# Altium schematic sheet [11] - HDMI INPUT.SchDoc("[11] - HDMI INPUT")
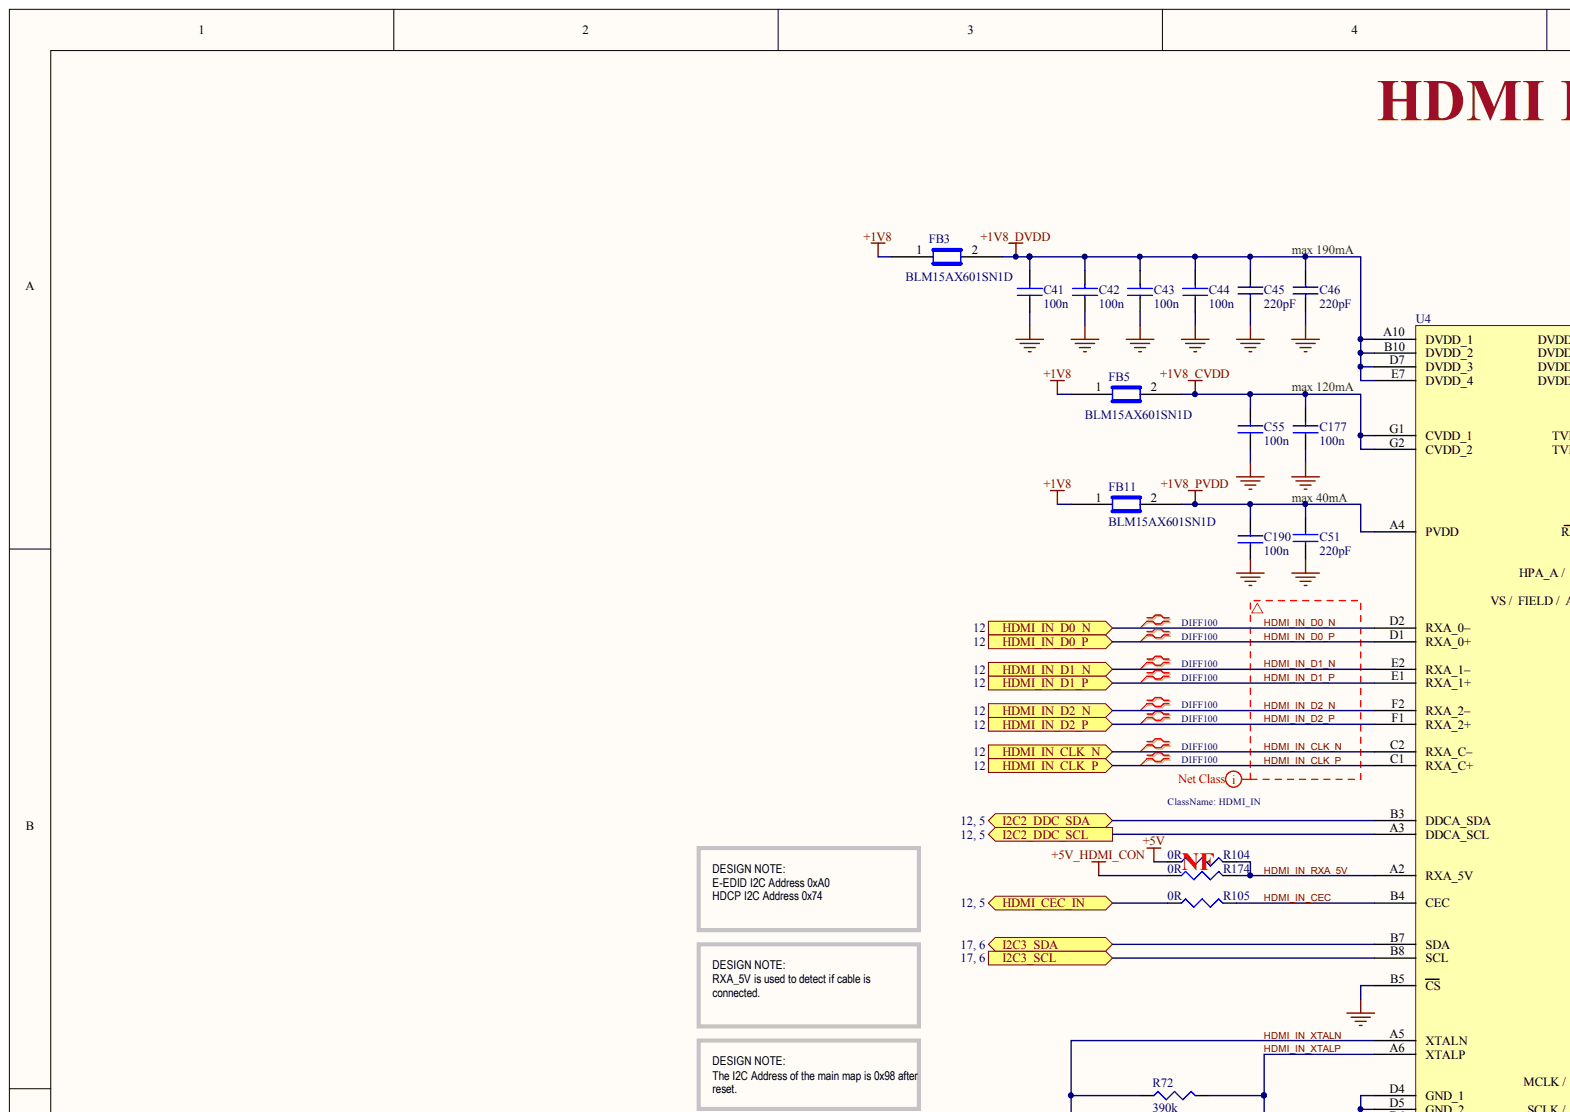
[diagram p0: [11] - HDMI INPUT.SchDoc, top left]
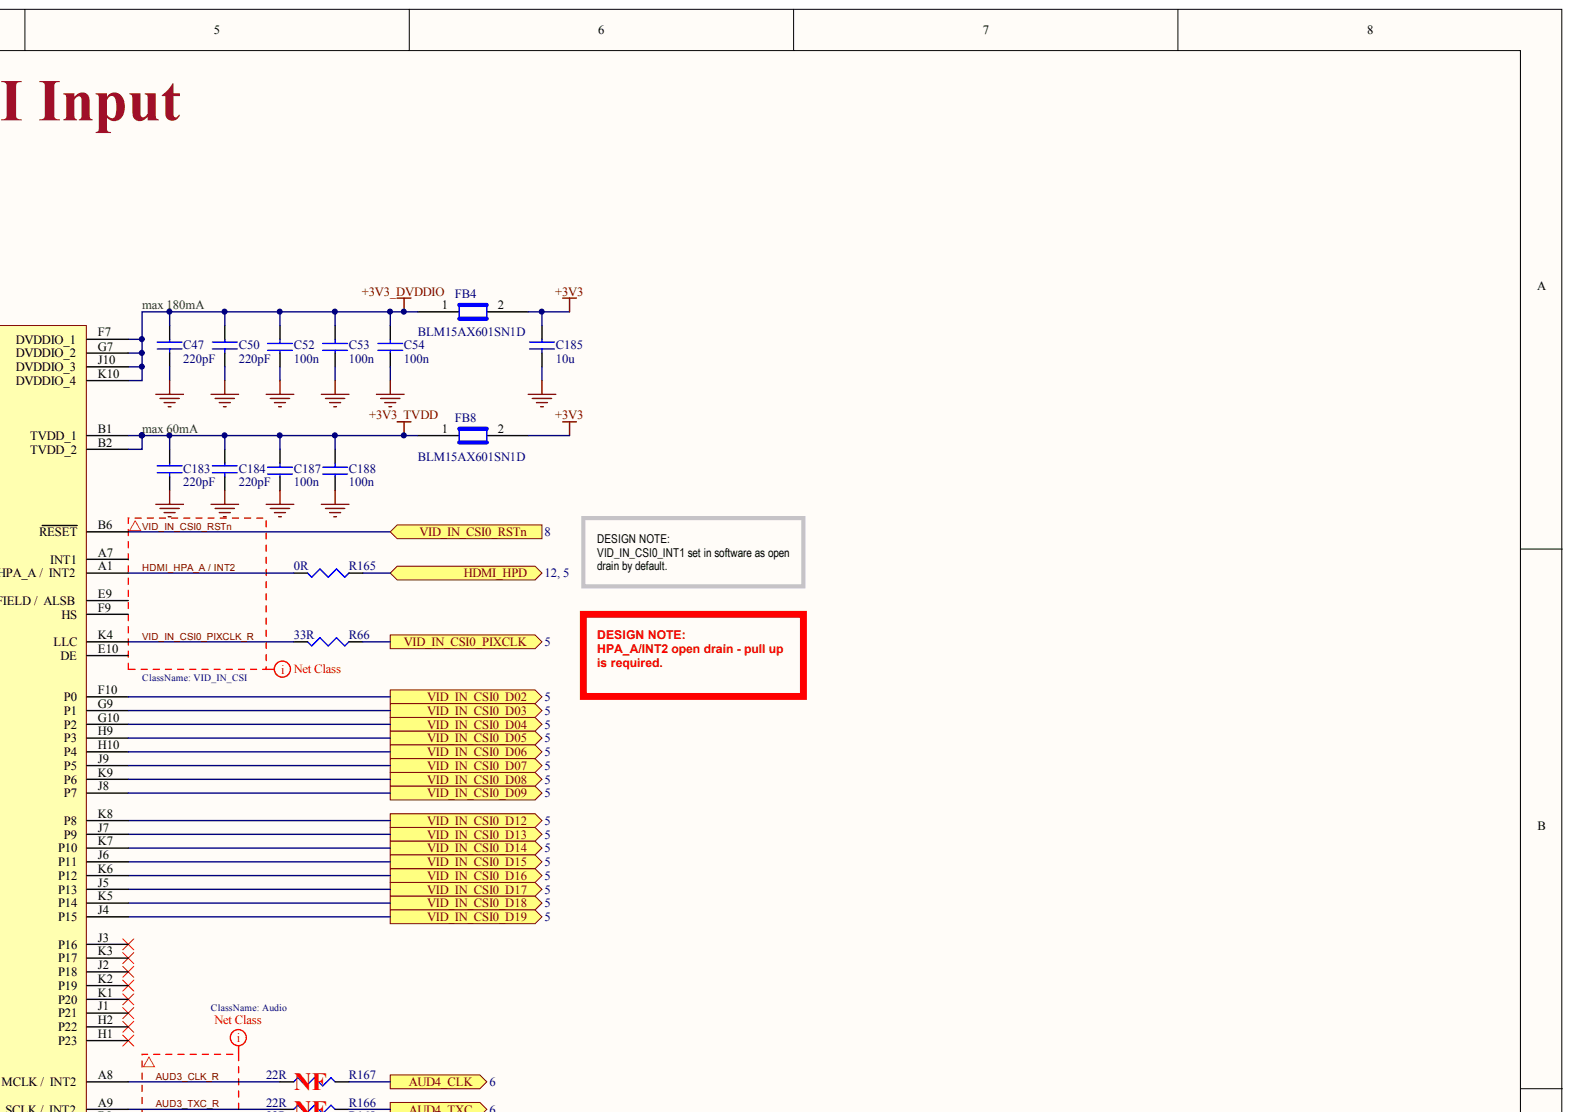
[diagram p1: [11] - HDMI INPUT.SchDoc, top right]
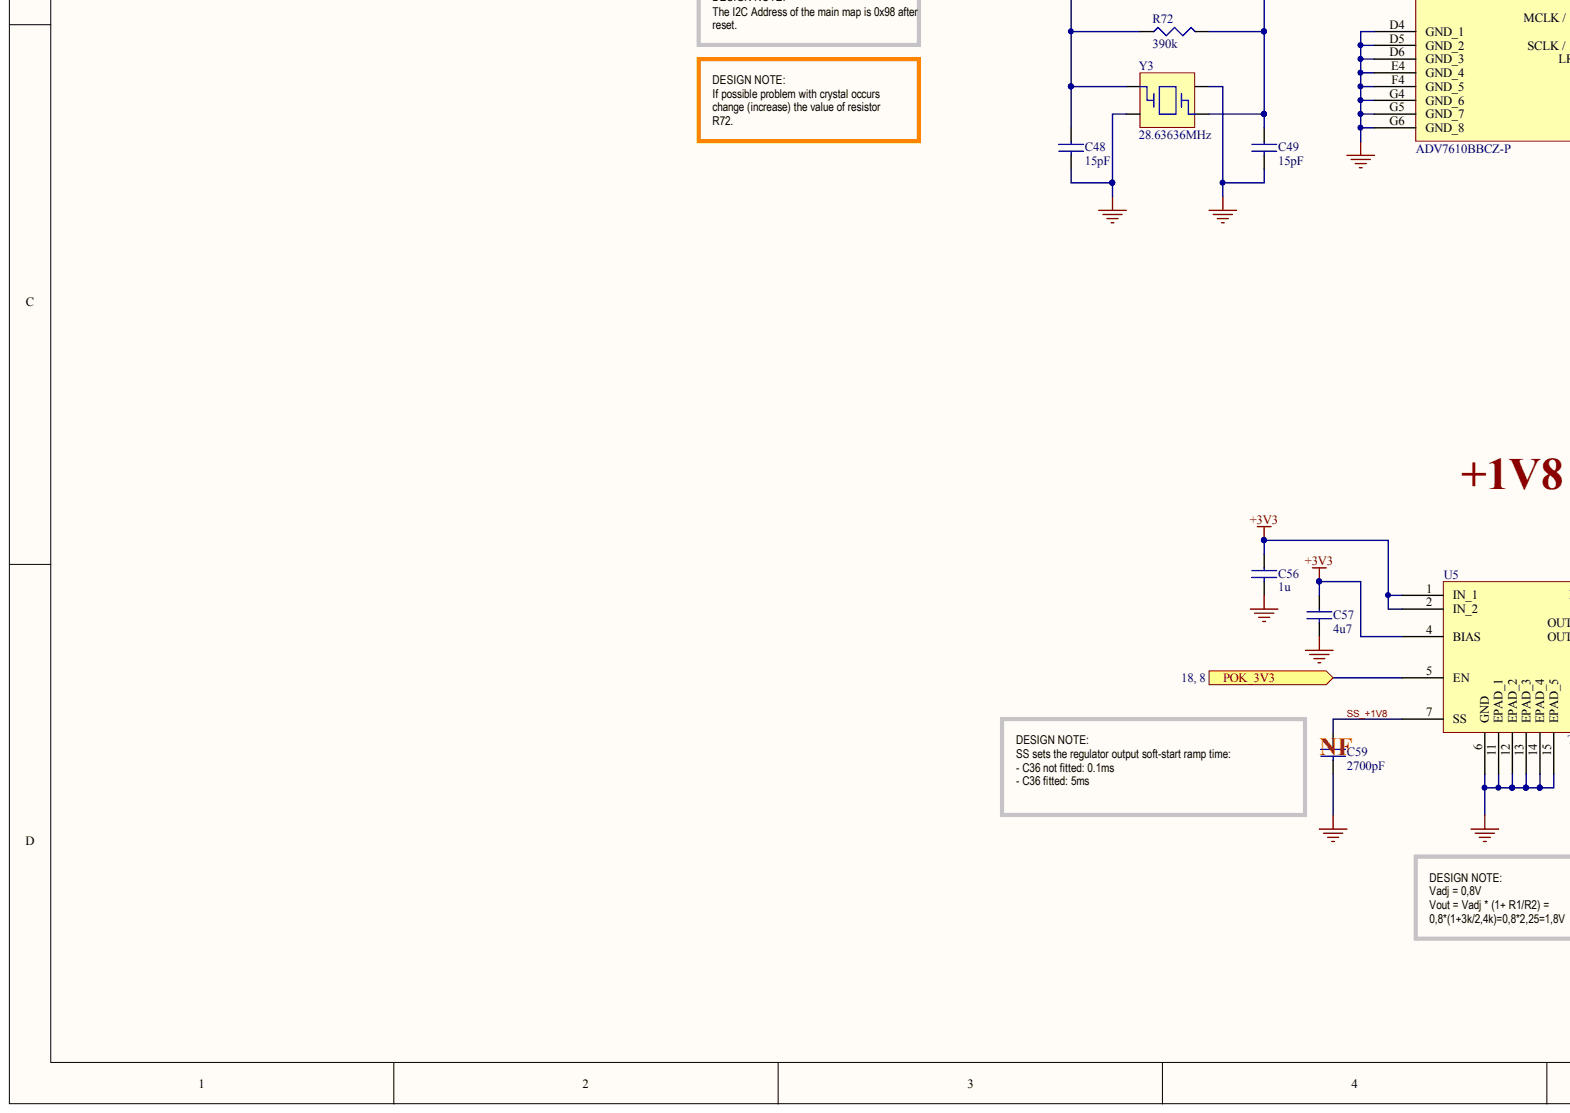
[diagram p2: [11] - HDMI INPUT.SchDoc, bottom left]
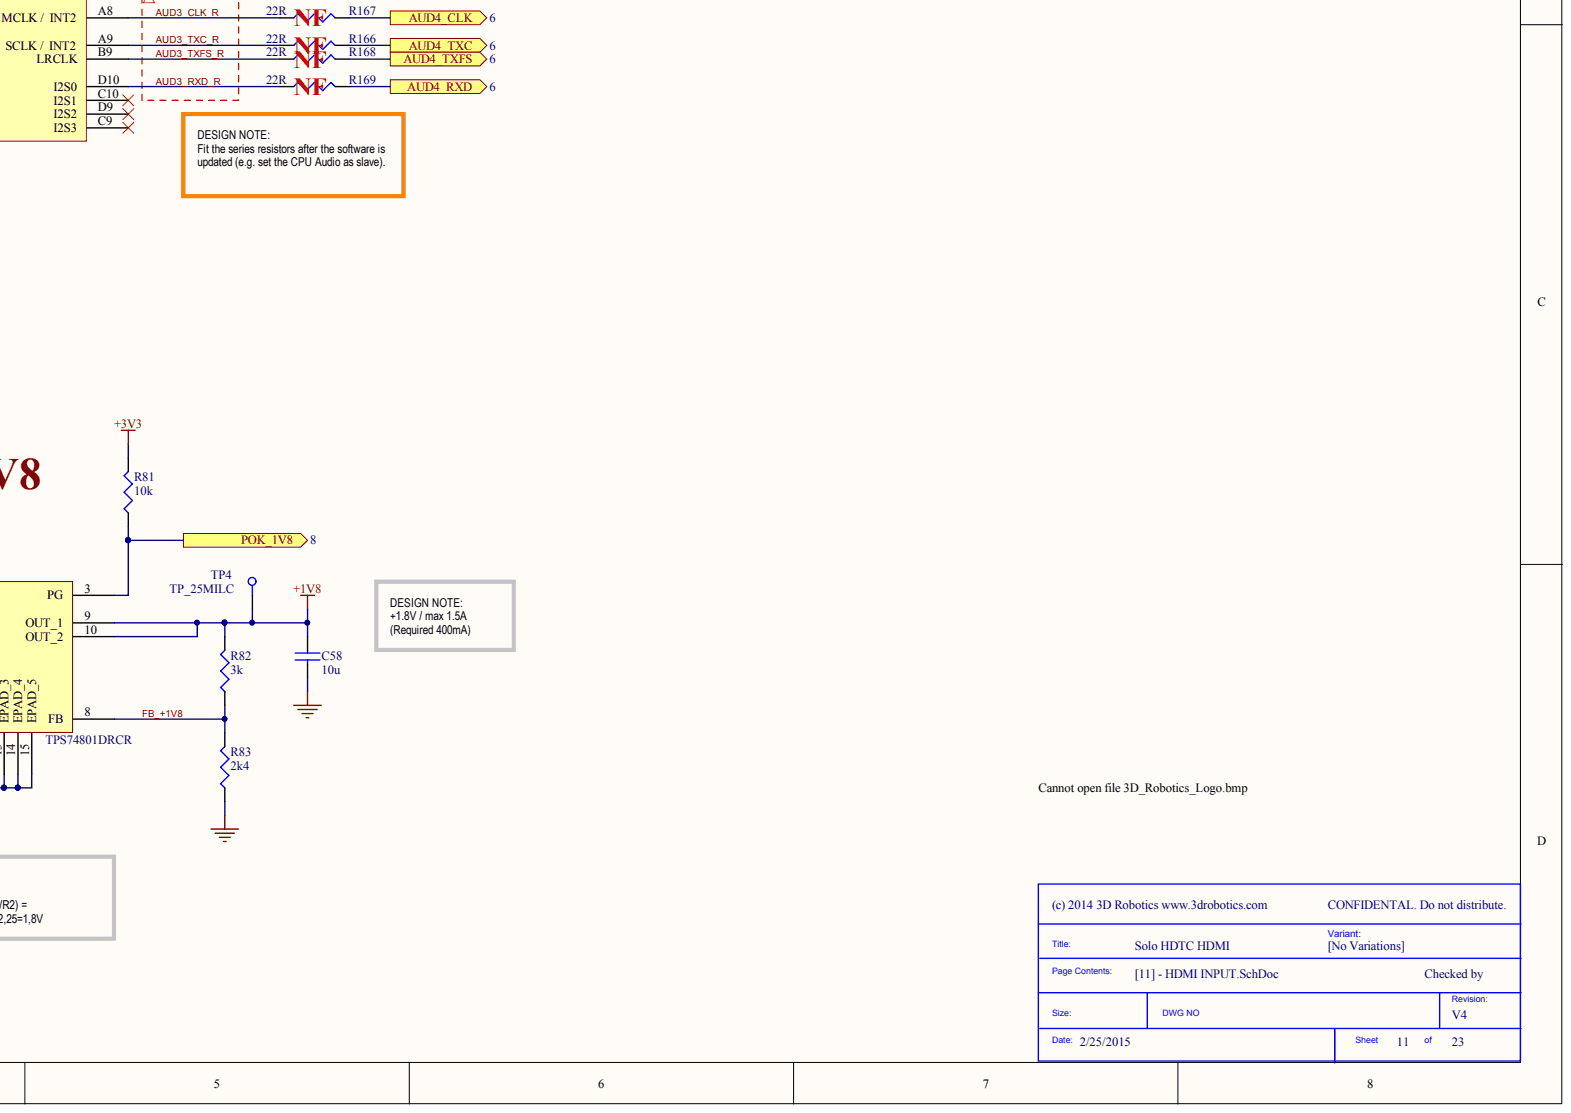
[diagram p3: [11] - HDMI INPUT.SchDoc, bottom right]

## Parts
C41  100n
C42  100n
C43  100n
C44  100n
C45  220pF
C46  220pF
C47  220pF
C48  15pF
C49  15pF
C50  220pF
C51  220pF
C52  100n
C53  100n
C54  100n
C55  100n
C56  1u
C57  4u7
C58  10u
C59  2700pF
C177  100n
C183  220pF
C184  220pF
C185  10u
C187  100n
C188  100n
C190  100n
FB3  BLM15AX601SN1D
FB4  BLM15AX601SN1D
FB5  BLM15AX601SN1D
FB8  BLM15AX601SN1D
FB11  BLM15AX601SN1D
R66  33R
R72  390k
R81  10k
R82  3k
R83  2k4
R104  0R
R105  0R
R165  0R
R166  22R
R167  22R
R168  22R
R169  22R
R174  0R
TP4  TP_25MILC
U4  ADV7610BBCZ-P
U5  TPS74801DRCR
Y3  28.63636MHz

## Ports
AUD4_CLK, AUD4_RXD, AUD4_TXC, AUD4_TXFS, HDMI_CEC_IN, HDMI_HPD, HDMI_IN_CLK_N, HDMI_IN_CLK_P, HDMI_IN_D0_N, HDMI_IN_D0_P, HDMI_IN_D1_N, HDMI_IN_D1_P, HDMI_IN_D2_N, HDMI_IN_D2_P, I2C2_DDC_SCL, I2C2_DDC_SDA, I2C3_SCL, I2C3_SDA, POK_1V8, POK_3V3, VID_IN_CSI0_D02, VID_IN_CSI0_D03, VID_IN_CSI0_D04, VID_IN_CSI0_D05, VID_IN_CSI0_D06, VID_IN_CSI0_D07, VID_IN_CSI0_D08, VID_IN_CSI0_D09, VID_IN_CSI0_D12, VID_IN_CSI0_D13, VID_IN_CSI0_D14, VID_IN_CSI0_D15, VID_IN_CSI0_D16, VID_IN_CSI0_D17, VID_IN_CSI0_D18, VID_IN_CSI0_D19, VID_IN_CSI0_PIXCLK, VID_IN_CSI0_RSTN

## Nets
+1V8: C58.1 FB3.1 FB5.1 FB11.1 R82.2 TP4.1 U5.9(OUT_1) U5.10(OUT_2)
+1V8_CVDD: C55.2 C177.2 FB5.2 U4.G1(CVDD_1) U4.G2(CVDD_2)
+1V8_DVDD: C41.2 C42.2 C43.2 C44.2 C45.1 C46.1 FB3.2 U4.A10(DVDD_1) U4.B10(DVDD_2) U4.D7(DVDD_3) U4.E7(DVDD_4)
+1V8_PVDD: C51.1 C190.2 FB11.2 U4.A4(PVDD)
+3V3: C56.1 C57.1 C185.1 FB4.2 FB8.2 R81.2 U5.1(IN_1) U5.2(IN_2) U5.4(BIAS)
+3V3_DVDDIO: C47.1 C50.1 C52.2 C53.2 C54.2 FB4.1 U4.F7(DVDDIO_1) U4.G7(DVDDIO_2) U4.J10(DVDDIO_3) U4.K10(DVDDIO_4)
+3V3_TVDD: C183.1 C184.1 C187.2 C188.2 FB8.1 U4.B1(TVDD_1) U4.B2(TVDD_2)
+5V: R104.1
+5V_HDMI_CON: R174.1
GND: C41.1 C42.1 C43.1 C44.1 C45.2 C46.2 C47.2 C48.2 C49.2 C50.2 C51.2 C52.1 C53.1 C54.1 C55.1 C56.2 C57.2 C58.2 C59.2 C177.1 C183.2 C184.2 C185.2 C187.1 C188.1 C190.1 R83.1 U4.B5(CS) U4.D4(GND_1) U4.D5(GND_2) U4.D6(GND_3) U4.E4(GND_4) U4.F4(GND_5) U4.G4(GND_6) U4.G5(GND_7) U4.G6(GND_8) U5.6(GND) U5.11(EPAD_1) U5.12(EPAD_2) U5.13(EPAD_3) U5.14(EPAD_4) U5.15(EPAD_5) Y3.2 Y3.4
AUD3_CLK_R: R167.1 U4.A8(INT2)
AUD3_RXD_R: R169.1 U4.D10(I2S0)
AUD3_TXC_R: R166.1 U4.A9(INT2)
AUD3_TXFS_R: R168.1 U4.B9(LRCLK)
AUD4_CLK: R167.2 ; port AUD4_CLK
AUD4_RXD: R169.2 ; port AUD4_RXD
AUD4_TXC: R166.2 ; port AUD4_TXC
AUD4_TXFS: R168.2 ; port AUD4_TXFS
FB_+1V8: R82.1 R83.2 U5.8(FB)
HDMI_CEC_IN: R105.1 ; port HDMI_CEC_IN
HDMI_HPA_A / INT2: R165.2 U4.A1(INT2)
HDMI_HPD: R165.1 ; port HDMI_HPD
HDMI_IN_CEC: R105.2 U4.B4(CEC)
HDMI_IN_CLK_N: U4.C2(RXA_C–) ; port HDMI_IN_CLK_N
HDMI_IN_CLK_P: U4.C1(RXA_C+) ; port HDMI_IN_CLK_P
HDMI_IN_D0_N: U4.D2(RXA_0–) ; port HDMI_IN_D0_N
HDMI_IN_D0_P: U4.D1(RXA_0+) ; port HDMI_IN_D0_P
HDMI_IN_D1_N: U4.E2(RXA_1–) ; port HDMI_IN_D1_N
HDMI_IN_D1_P: U4.E1(RXA_1+) ; port HDMI_IN_D1_P
HDMI_IN_D2_N: U4.F2(RXA_2–) ; port HDMI_IN_D2_N
HDMI_IN_D2_P: U4.F1(RXA_2+) ; port HDMI_IN_D2_P
HDMI_IN_RXA_5V: R104.2 R174.2 U4.A2(RXA_5V)
HDMI_IN_XTALN: C48.1 R72.1 U4.A5(XTALN) Y3.1
HDMI_IN_XTALP: C49.1 R72.2 U4.A6(XTALP) Y3.3
I2C2_DDC_SCL: U4.A3(DDCA_SCL) ; port I2C2_DDC_SCL
I2C2_DDC_SDA: U4.B3(DDCA_SDA) ; port I2C2_DDC_SDA
I2C3_SCL: U4.B8(SCL) ; port I2C3_SCL
I2C3_SDA: U4.B7(SDA) ; port I2C3_SDA
POK_1V8: R81.1 U5.3(PG) ; port POK_1V8
POK_3V3: U5.5(EN) ; port POK_3V3
SS_+1V8: C59.1 U5.7(SS)
VID_IN_CSI0_D02: U4.F10(P0) ; port VID_IN_CSI0_D02
VID_IN_CSI0_D03: U4.G9(P1) ; port VID_IN_CSI0_D03
VID_IN_CSI0_D04: U4.G10(P2) ; port VID_IN_CSI0_D04
VID_IN_CSI0_D05: U4.H9(P3) ; port VID_IN_CSI0_D05
VID_IN_CSI0_D06: U4.H10(P4) ; port VID_IN_CSI0_D06
VID_IN_CSI0_D07: U4.J9(P5) ; port VID_IN_CSI0_D07
VID_IN_CSI0_D08: U4.K9(P6) ; port VID_IN_CSI0_D08
VID_IN_CSI0_D09: U4.J8(P7) ; port VID_IN_CSI0_D09
VID_IN_CSI0_D12: U4.K8(P8) ; port VID_IN_CSI0_D12
VID_IN_CSI0_D13: U4.J7(P9) ; port VID_IN_CSI0_D13
VID_IN_CSI0_D14: U4.K7(P10) ; port VID_IN_CSI0_D14
VID_IN_CSI0_D15: U4.J6(P11) ; port VID_IN_CSI0_D15
VID_IN_CSI0_D16: U4.K6(P12) ; port VID_IN_CSI0_D16
VID_IN_CSI0_D17: U4.J5(P13) ; port VID_IN_CSI0_D17
VID_IN_CSI0_D18: U4.K5(P14) ; port VID_IN_CSI0_D18
VID_IN_CSI0_D19: U4.J4(P15) ; port VID_IN_CSI0_D19
VID_IN_CSI0_PIXCLK: R66.1 ; port VID_IN_CSI0_PIXCLK
VID_IN_CSI0_PIXCLK_R: R66.2 U4.K4(LLC)
VID_IN_CSI0_RSTN: U4.B6(RESET) ; port VID_IN_CSI0_RSTN
N00577:
N00578:
N00579:

Not connected: U4 A7(INT1) C9(I2S3) C10(I2S1) D9(I2S2) E9(ALSB) E10(DE) F9(HS) H1(P23) H2(P22) J1(P21) J2(P18) J3(P16) K1(P20) K2(P19) K3(P17)

## Library data of the parts
C41-C44 C52-C55 C177 C187 C188 C190 = (no symbol name): Manufacturer: TDK Corporation; Manufacturer Part Number: C1005X5R1H104K; Capacitance: 0.1µF; Value: 100nF; .Checked PCB: used; Voltage - Rated: 50V; Tolerance: ±10%; Temperature Coefficient: X5R; Category: Capacitors; Supplier Part Number: 445-5942-1-ND; Operating Temperature: -55°C ~ 85°C; Package / Case: 0402 (1005 Metric); Price 1k [$]: 0.02340; .Checked SCH: used; Supplier: Digikey; Supplier 1: Digi-Key; Supplier Part Number 1: 445-5942-1-ND; RoHS: RoHS Compliant; Stock 1: 106926; Pricing 1: 1=0.27, 10=0.18, 100=0.072, 250=0.05176, 500=0.0369, 1000=0.027 (USD); Mounting Type: Surface Mount, MLCC; Applications: General Purpose; Ratings: -; Size / Dimension: 0.039" L x 0.020" W (1.00mm x 0.50mm); Height - Seated (Max): -; Thickness (Max): 0.022" (0.55mm); Lead Spacing: -; Features: -; Packaging: Cut Tape (CT); Lead Style: -; ComponentLink1URL: http://www.tdk.co.jp/tefe02/e412_c.pdf; ComponentLink1Description: http://www.tdk.co.jp/tefe02/e412_c.pdf
C45-C47 C50 C51 C183 C184 = (no symbol name): Manufacturer: TDK Corporation; Manufacturer Part Number: C1005X7R1H221K; .Checked PCB: used; Voltage - Rated: 50V; Tolerance: ±10%; Temperature Coefficient: X7R; Capacitance: 220pF; Category: Capacitors; Supplier Part Number: 445-1254-1-ND; Operating Temperature: -55°C ~ 125°C; Package / Case: 0402 (1005 Metric); Price 1k [$]: 0.00650; Value: 220pF; .Checked SCH: used; Supplier: Digikey; Supplier 1: Digi-Key; Supplier Part Number 1: 445-1254-1-ND; RoHS: RoHS Compliant; Stock 1: 212041; Pricing 1: 1=0.08, 10=0.05, 100=0.018, 250=0.013, 500=0.0096, 1000=0.0065 (USD); Mounting Type: Surface Mount, MLCC; Applications: General Purpose; Ratings: -; Size / Dimension: 0.039" L x 0.020" W (1.00mm x 0.50mm); Height - Seated (Max): -; Thickness (Max): 0.022" (0.55mm); Lead Spacing: -; Features: -; Packaging: Cut Tape (CT); Lead Style: -; ComponentLink1URL: http://www.tdk.co.jp/tefe02/e412_c.pdf; ComponentLink1Description: http://www.tdk.co.jp/tefe02/e412_c.pdf
C48 C49 = (no symbol name): Manufacturer: TDK Corporation; Manufacturer Part Number: C1005C0G1H150J; Voltage - Rated: 50V; Tolerance: ±5%; Temperature Coefficient: C0G, NP0; .Checked PCB: RF; Supplier 1: Digi-Key; Supplier Part Number 1: 445-1237-1-ND; RoHS: RoHS Compliant; Category: Capacitors; Stock 1: 204632; Pricing 1: 10=0.024, 100=0.011, 250=0.009, 500=0.0077, 1000=0.006, 5000=0.005 (USD); Capacitance: 15pF; Mounting Type: Surface Mount, MLCC; Operating Temperature: -55°C ~ 125°C; Applications: General Purpose; Ratings: -; Package / Case: 0402 (1005 Metric); Size / Dimension: 0.039" L x 0.020" W (1.00mm x 0.50mm); Height - Seated (Max): -; Thickness (Max): 0.022" (0.55mm); Lead Spacing: -; Features: -; Packaging: Cut Tape (CT); Lead Style: -; ComponentLink1URL: http://www.tdk.com/pdf/general_B11.pdf; ComponentLink1Description: http://www.tdk.com/pdf/general_B11.pdf; .Checked SCH: RF
C56 = (no symbol name): Manufacturer: TDK Corporation; Manufacturer Part Number: C1005X5R1C105K; Capacitance: 1.0µF; Value: 1uF; .Checked PCB: used; Voltage - Rated: 16V; Tolerance: ±10%; Temperature Coefficient: X5R; Category: Capacitors; Supplier Part Number: 445-4978-1-ND; Operating Temperature: -55°C ~ 85°C; Package / Case: 0402 (1005 Metric); Price 1k [$]: 0.02080; .Checked SCH: used; Supplier: Digikey; Supplier 1: Digi-Key; Supplier Part Number 1: 445-4978-1-ND; RoHS: RoHS Compliant; Stock 1: 341010; Pricing 1: 1=0.24, 10=0.16, 100=0.064, 250=0.046, 500=0.0328, 1000=0.024 (USD); Mounting Type: Surface Mount, MLCC; Applications: General Purpose; Ratings: -; Size / Dimension: 0.039" L x 0.020" W (1.00mm x 0.50mm); Height - Seated (Max): -; Thickness (Max): 0.022" (0.55mm); Lead Spacing: -; Features: -; Packaging: Cut Tape (CT); Lead Style: -; ComponentLink1URL: http://www.tdk.co.jp/tefe02/e412_c.pdf; ComponentLink1Description: http://www.tdk.co.jp/tefe02/e412_c.pdf
C57 = (no symbol name): Manufacturer: TDK Corporation; Manufacturer Part Number: C1005X5R1A475M; Capacitance: 4.7µF; Voltage - Rated: 10V; Tolerance: ±20%; Temperature Coefficient: X5R; .Checked PCB: RF; Supplier 1: Digi-Key; Supplier Part Number 1: 445-8023-1-ND; RoHS: RoHS Compliant; Category: Capacitors; Stock 1: 10140; Pricing 1: 1=0.53, 10=0.415, 100=0.25, 250=0.18752, 500=0.16, 1000=0.125, 5000=0.095 (USD); Mounting Type: Surface Mount, MLCC; Operating Temperature: -55°C ~ 85°C; Applications: General Purpose; Ratings: -; Package / Case: 0402 (1005 Metric); Size / Dimension: 0.039" L x 0.020" W (1.00mm x 0.50mm); Height - Seated (Max): -; Thickness (Max): -; Lead Spacing: -; Features: -; Packaging: Cut Tape (CT); Lead Style: -; ComponentLink1URL: http://www.tdk.com/pdf/general_B11.pdf; ComponentLink1Description: http://www.tdk.com/pdf/general_B11.pdf; .Checked SCH: RF
C58 C185 = (no symbol name): Manufacturer: TDK Corporation; Manufacturer Part Number: C1608X5R0J106M; Capacitance: 10µF; Value: 10µF; .Checked PCB: used; Voltage - Rated: 6.3V; Tolerance: ±20%; Temperature Coefficient: X5R; Category: Capacitors; Supplier Part Number: 445-4112-1-ND; Operating Temperature: -55°C ~ 85°C; Package / Case: 0603 (1608 Metric); Price 1k [$]: 0.08580; .Checked SCH: used; Features: Low ESR; Supplier: Digikey; Supplier 1: Digi-Key; Supplier Part Number 1: 445-4112-1-ND; RoHS: RoHS Compliant; Stock 1: 48157; Pricing 1: 1=0.46, 10=0.363, 100=0.1898, 250=0.14024, 500=0.1089, 1000=0.0858 (USD); Mounting Type: Surface Mount, MLCC; Applications: General Purpose; Ratings: -; Size / Dimension: 0.063" L x 0.031" W (1.60mm x 0.80mm); Height - Seated (Max): -; Thickness (Max): 0.037" (0.95mm); Lead Spacing: -; Packaging: Cut Tape (CT); Lead Style: -; ComponentLink1URL: http://www.tdk.com/pdf/general_B11.pdf; ComponentLink1Description: http://www.tdk.com/pdf/general_B11.pdf
C59 = (no symbol name): Manufacturer: Murata Electronics North America; Manufacturer Part Number: GRM155R71H272KA01D; Voltage - Rated: 50V; Tolerance: ±10%; Temperature Coefficient: X7R; .Checked PCB: RF; Supplier 1: Digi-Key; Supplier Part Number 1: 490-1306-1-ND; RoHS: RoHS Compliant; Category: Capacitors; Stock 1: 38160; Pricing 1: 10=0.01, 50=0.0086, 100=0.0074, 250=0.00664, 500=0.00618, 750=0.00571, 1000=0.00542 (USD); Capacitance: 2700pF; Mounting Type: Surface Mount, MLCC; Operating Temperature: -55°C ~ 125°C; Applications: General Purpose; Ratings: -; Package / Case: 0402 (1005 Metric); Size / Dimension: 0.039" L x 0.020" W (1.00mm x 0.50mm); Height - Seated (Max): -; Thickness (Max): 0.022" (0.55mm); Lead Spacing: -; Features: -; Packaging: Cut Tape (CT); Lead Style: -; ComponentLink1URL: http://search.murata.co.jp/Ceramy/image/img/PDF/ENG/GRM155R71H272KA01.pdf; ComponentLink1Description: http://search.murata.co.jp/Ceramy/image/img/PDF/ENG/GRM155R71H272KA01.pdf; .Checked SCH: RF
FB3-FB5 FB8 FB11 = (no symbol name): Manufacturer: Murata Electronics North America; .Checked PCB: used; Impedance @ Frequency: 600 Ohm @ 100MHz; Current Rating: 420mA; DC Resistance (DCR): 340 mOhm Max; Filter Type: Differential Mode - Single; Number of Lines: 1; .Checked SCH: used; Category: Filters; Supplier Part Number: 490-5441-1-ND; Manufacturer Part Number: BLM15AX601SN1D; Package / Case: 0402 (1005 Metric); Price 1k [$]: 0.03280; Value: BLM15AX601SN1D; Supplier: Digikey; Supplier 1: Digi-Key; Supplier Part Number 1: 490-5441-1-ND; RoHS: RoHS Compliant; Stock 1: 34008; Pricing 1: 1=0.26, 10=0.197, 50=0.1148, 100=0.0918, 250=0.0656, 500=0.0446, 1000=0.03608, 5000=0.02821 (USD); Mounting Type: Surface Mount; Packaging: Cut Tape (CT); Height (Max): 0.022" (0.55mm); Size / Dimension: 0.039" L x 0.020" W (1.00mm x 0.50mm); ComponentLink1URL: http://search.murata.co.jp/Ceramy/image/img/PDF/ENG/L0110S0100BLM15AX_SN.pdf; ComponentLink1Description: http://search.murata.co.jp/Ceramy/image/img/PDF/ENG/L0110S0100BLM15AX_SN.pdf
R66 = (no symbol name): Manufacturer: Stackpole Electronics Inc; Manufacturer Part Number: RMCF0402FT33R0; Resistance (Ohms): 33; Power (Watts): 0.063W, 1/16W; Tolerance: ±1%; .Checked PCB: RF; Temperature Coefficient: ±100ppm/°C; Category: Resistors; Supplier Part Number: RMCF0402FT33R0CT-ND; Package / Case: 0402 (1005 Metric); Price 1k [$]: 0.00384; Value: 33R; Supplier: Digikey; Supplier 1: Digi-Key; Supplier Part Number 1: RMCF0402FT33R0CT-ND; RoHS: RoHS Compliant; Stock 1: 26866; Pricing 1: 1=0.04, 10=0.025, 50=0.0138, 100=0.0105, 250=0.0078, 500=0.00576, 1000=0.00384 (USD); Composition: Thick Film; Features: -; Size / Dimension: 0.039" L x 0.020" W (1.00mm x 0.50mm); Height: 0.016" (0.40mm); Number of Terminations: 2; Packaging: Cut Tape (CT); ComponentLink1URL: http://www.seielect.com/Catalog/SEI-RMCF.pdf; ComponentLink1Description: http://www.seielect.com/Catalog/SEI-RMCF.pdf; .Checked SCH: RF
R72 = (no symbol name): Manufacturer: Vishay Dale; Manufacturer Part Number: CRCW0402390KFKED; Tolerance: ±1%; Power (Watts): 0.063W, 1/16W; Temperature Coefficient: ±100ppm/°C; .Creator: MM; Supplier 1: Digi-Key; RoHS: RoHS Compliant; Category: Resistors; Stock 1: 288225; Pricing 1: 10=0.083, 50=0.0444, 200=0.0255, 1000=0.0174 (USD); Packaging: Cut Tape (CT); Resistance (Ohms): 390k; Composition: Thick Film; Features: Automotive AEC-Q200 Qualified; Package / Case: 0402 (1005 Metric); Supplier Device Package: 0402 (1005 Metric); Size / Dimension: 0.039" L x 0.020" W (1.00mm x 0.50mm); Height: 0.016" (0.40mm); Number of Terminations: 2; ComponentLink1URL: http://www.vishay.com/docs/20035/dcrcwe3.pdf; ComponentLink1Description: http://www.vishay.com/docs/20035/dcrcwe3.pdf; Supplier Part Number 1: 541-390KLCT-ND; .Checked SCH: MM; .Checked PCB: MM
R81 = (no symbol name): Manufacturer: Yageo; Manufacturer Part Number: RC0402FR-0710KL; Power (Watts): 0.063W, 1/16W; Tolerance: ±1%; .Checked PCB: used; Temperature Coefficient: ±100ppm/°C; Category: Resistors; Package / Case: 0402 (1005 Metric); Price 1k [$]: 0.01421; Resistance (Ohms): 10K; Value: 10k; .Checked SCH: used; Supplier Part Number: 311-10.0KLRCT-ND; Supplier: Digikey; Supplier 1: Digi-Key; Supplier Part Number 1: 311-10.0KLRCT-ND; RoHS: RoHS Compliant; Stock 1: 2916495; Pricing 1: 10=0.014, 50=0.0104, 100=0.0079, 250=0.00584, 500=0.00432, 1000=0.00288 (USD); Composition: Thick Film; Features: -; Size / Dimension: 0.039" L x 0.020" W (1.00mm x 0.50mm); Height: 0.016" (0.40mm); Number of Terminations: 2; Packaging: Cut Tape (CT); ComponentLink1URL: http://www.yageo.com/documents/recent/PYu-RC0402_51_RoHS_L_6.pdf; ComponentLink1Description: http://www.yageo.com/documents/recent/PYu-RC0402_51_RoHS_L_6.pdf
R82 = (no symbol name): Manufacturer: Yageo; Manufacturer Part Number: RC0402FR-073KL; Tolerance: ±1%; Power (Watts): 0.063W, 1/16W; Temperature Coefficient: ±100ppm/°C; .Creator: MM; .Checked SCH: RF, used by MM; Supplier 1: Digi-Key; RoHS: RoHS Compliant; Category: Resistors; Stock 1: 194271; Pricing 1: 1=0.1, 10=0.014, 25=0.0104, 100=0.0063, 250=0.0054, 500=0.00382, 1000=0.0027, 2500=0.00234, 5000=0.00203 (USD); Packaging: Cut Tape (CT); Resistance (Ohms): 3k; Composition: Thick Film; Features: -; Package / Case: 0402 (1005 Metric); Supplier Device Package: 0402; Size / Dimension: 0.039" L x 0.020" W (1.00mm x 0.50mm); Height: 0.016" (0.40mm); Number of Terminations: 2; ComponentLink1URL: http://www.yageo.com/documents/recent/PYu-RC0402_51_RoHS_L_6.pdf; ComponentLink1Description: http://www.yageo.com/documents/recent/PYu-RC0402_51_RoHS_L_6.pdf; Supplier Part Number 1: 311-3KLRCT-ND; .Checked PCB: RF, used by MM
R83 = (no symbol name): Manufacturer: Vishay Dale; Manufacturer Part Number: CRCW04022K40FKED; Resistance (Ohms): 2.4K; Power (Watts): 0.063W, 1/16W; Temperature Coefficient: ±100ppm/°C; Tolerance: ±1%; .Checked PCB: RF; Supplier 1: Digi-Key; Supplier Part Number 1: 541-2.40KLCT-ND; RoHS: RoHS Compliant; Category: Resistors; Stock 1: 43967; Pricing 1: 10=0.083, 50=0.0444, 200=0.0255, 1000=0.0174 (USD); Composition: Thick Film; Features: -; Package / Case: 0402 (1005 Metric); Size / Dimension: 0.039" L x 0.020" W (1.00mm x 0.50mm); Height: 0.016" (0.40mm); Number of Terminations: 2; Packaging: Cut Tape (CT); ComponentLink1URL: http://www.vishay.com/doc?20035; ComponentLink1Description: http://www.vishay.com/doc?20035; .Checked SCH: RF
R104 R105 R165 R174 = (no symbol name): Manufacturer: Yageo; Manufacturer Part Number: RC0402JR-070RL; Resistance (Ohms): 0.0; Power (Watts): 0.063W, 1/16W; Tolerance: Jumper; .Checked PCB: used; Category: Resistors; Supplier Part Number: 311-0.0JRCT-ND; Package / Case: 0402 (1005 Metric); Price 1k [$]: 0.01389; Value: 0R; .Checked SCH: used; Supplier: Digikey; Supplier 1: Digi-Key; Supplier Part Number 1: 311-0.0JRCT-ND; RoHS: RoHS Compliant; Stock 1: 1106330; Pricing 1: 10=0.012, 50=0.009, 100=0.0069, 250=0.00512, 500=0.0038, 1000=0.00253 (USD); Composition: Thick Film; Features: -; Temperature Coefficient: -; Size / Dimension: 0.039" L x 0.020" W (1.00mm x 0.50mm); Height: 0.016" (0.40mm); Number of Terminations: 2; Packaging: Cut Tape (CT); ComponentLink1URL: http://www.yageo.com/documents/recent/PYu-RC0402_51_RoHS_L_6.pdf; ComponentLink1Description: http://www.yageo.com/documents/recent/PYu-RC0402_51_RoHS_L_6.pdf
R166-R169 = (no symbol name): Manufacturer: Yageo; Manufacturer Part Number: RC0402FR-0722RL; Resistance (Ohms): 22; Power (Watts): 0.063W, 1/16W; Tolerance: ±1%; .Checked PCB: used; Temperature Coefficient: ±100ppm/°C; Category: Resistors; Supplier Part Number: 311-22.0LRCT-ND; Package / Case: 0402 (1005 Metric); Price 1k [$]: 0.01421; Value: 22R; .Checked SCH: used; Supplier: Digikey; Supplier 1: Digi-Key; Supplier Part Number 1: 311-22.0LRCT-ND; RoHS: RoHS Compliant; Stock 1: 943800; Pricing 1: 10=0.014, 50=0.0104, 100=0.0079, 250=0.00584, 500=0.00432, 1000=0.00288 (USD); Composition: Thick Film; Features: -; Size / Dimension: 0.039" L x 0.020" W (1.00mm x 0.50mm); Height: 0.016" (0.40mm); Number of Terminations: 2; Packaging: Cut Tape (CT); ComponentLink1URL: http://www.yageo.com/documents/recent/PYu-RC0402_51_RoHS_L_6.pdf; ComponentLink1Description: http://www.yageo.com/documents/recent/PYu-RC0402_51_RoHS_L_6.pdf
U4 = (no symbol name): Manufacturer: Analog Devices Inc; .Creator: MM; Supplier 1: Digi-Key; Manufacturer Part Number: ADV7610BBCZ-P; RoHS: RoHS Compliant; Category: Integrated Circuits (ICs); Stock 1: 47; Pricing 1: 1=14.23, 10=12.938, 100=10.9974, 250=10.02704, 500=9.38012, 1000=8.60384, 2500=8.484 (USD); Packaging: Tray; Type: HDMI, Receiver; Applications: Digital Video; Mounting Type: Surface Mount; Package / Case: 76-LFBGA, CSPBGA; Supplier Device Package: 76-CSPBGA (6x6); ComponentLink1URL: http://www.analog.com/static/imported-files/data_sheets/ADV7610.pdf; ComponentLink1Description: http://www.analog.com/static/imported-files/data_sheets/ADV7610.pdf; Supplier Part Number 1: ADV7610BBCZ-P-ND; .Checked PCB: MM; .Checked SCH: MM
U5 = (no symbol name): Manufacturer: Texas Instruments; Number of Regulators: 1; Regulator Topology: Positive Adjustable; Voltage - Input: 0.8 V ~ 5.5 V; Voltage - Output: 0.8 V ~ 3.6 V; Voltage - Dropout (Typical): 0.06V @ 1.5A; Current - Output: 1.5A (Max); Current - Limit (Min): 2A; .Creator: MM; .Checked PCB: RF, used by MM; Supplier 1: Digi-Key; Manufacturer Part Number: TPS74801DRCR; RoHS: RoHS Compliant; Category: Integrated Circuits (ICs); Stock 1: 11680; Pricing 1: 1=2.3, 10=2.074, 25=1.8576, 100=0.972, 250=0.954, 500=0.936, 750=0.918 (USD); Packaging: Cut Tape (CT); Operating Temperature: -40°C ~ 125°C; Mounting Type: Surface Mount; Package / Case: 10-VFDFN Exposed Pad; Supplier Device Package: 10-SON (3x3); ComponentLink1URL: http://www.ti.com/lit/ds/symlink/tps74801.pdf; ComponentLink1Description: http://www.ti.com/lit/ds/symlink/tps74801.pdf; Supplier Part Number 1: 296-21563-1-ND; .Checked SCH: MM
Y3 = (no symbol name): Manufacturer: Abracon Corporation; Manufacturer Part Number: ABM3B-28.63636MHZ-10-1-U-T; Frequency Stability: ±10ppm; Load Capacitance: 10pF; ESR (Equivalent Series Resistance): 50 Ohm; Operating Mode: Fundamental; .Creator: MM; .Checked PCB: MM, paper model not checked; Supplier 1: Digi-Key; RoHS: RoHS Compliant; Category: Crystals and Oscillators; Stock 1: 889; Pricing 1: 1=1.09, 10=0.968, 50=0.904, 100=0.8, 500=0.756 (USD); Packaging: Cut Tape (CT); Type: MHz Crystal; Frequency: 28.63636MHz; Frequency Tolerance: ±10ppm; Operating Temperature: -10°C ~ 60°C; Ratings: -; Mounting Type: Surface Mount; Package / Case: 4-SMD, No Lead (DFN, LCC); Size / Dimension: 0.197" L x 0.126" W (5.00mm x 3.20mm); Height: 0.043" (1.10mm); ComponentLink1URL: http://www.abracon.com/Resonators/abm3b.pdf; ComponentLink1Description: http://www.abracon.com/Resonators/abm3b.pdf; Supplier Part Number 1: 300-8220-1-ND; .Checked SCH: MM
